# Altium schematic sheet GAP_JTAG_XTALs.SchDoc
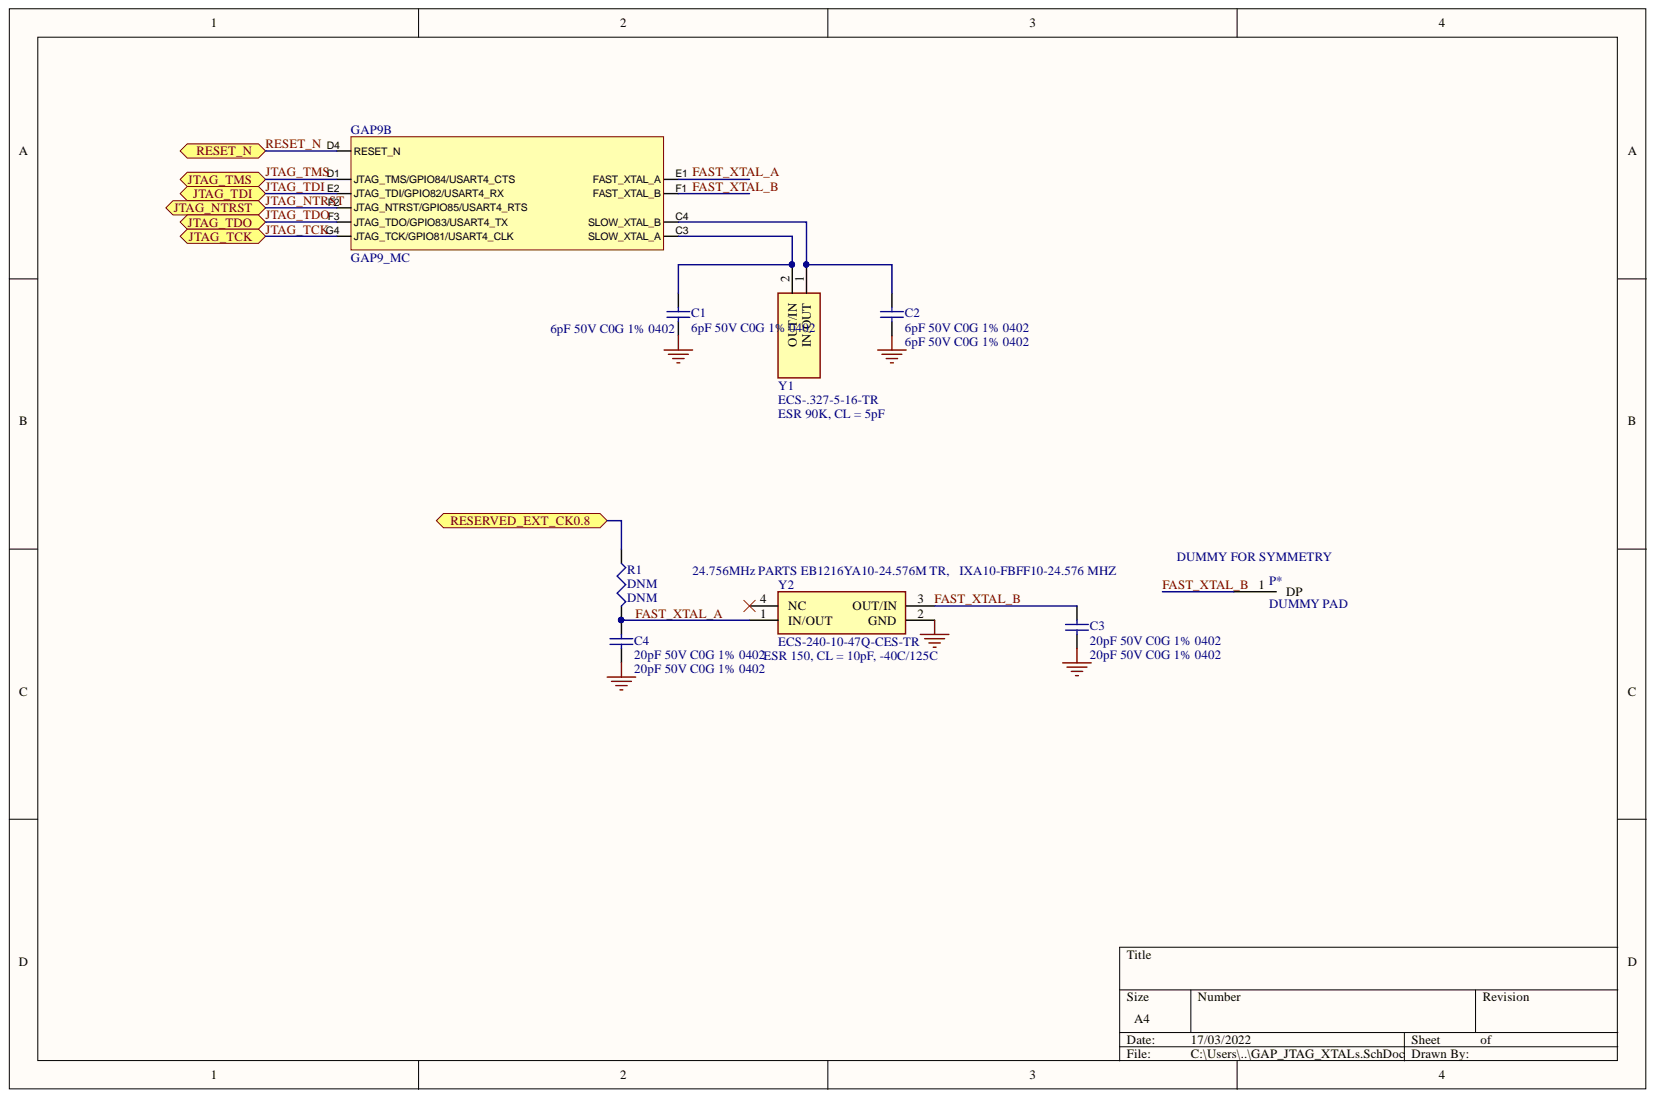
[diagram p0: GAP_JTAG_XTALs.SchDoc]

## Parts
C1  6pF 50V C0G 1% 0402  [0402]
C2  6pF 50V C0G 1% 0402  [0402]
C3  20pF 50V C0G 1% 0402  [0402]
C4  20pF 50V C0G 1% 0402  [0402]
GAP9  GAP9_MC  [GAP9]  (part B)
P*  DUMMY PAD  [0402_PAD]
R1  DNM  [0402]
Y1  ECS-.327-5-16-TR  [ECS327516TR]
Y2  ECS-240-10-47Q-CES-TR  [ECS260847QCESTR]

## Ports
JTAG_NTRST, JTAG_TCK, JTAG_TDI, JTAG_TDO, JTAG_TMS, RESERVED_EXT_CK0.8, RESET_N

## Nets
GND: C1.1 C2.1 C3.1 C4.1 Y2.2(GND)
FAST_XTAL_A: C4.2 GAP9.E1(FAST_XTAL_A) R1.2 Y2.1(IN/OUT)
FAST_XTAL_B: C3.2 GAP9.F1(FAST_XTAL_B) P*.1 Y2.3(OUT/IN)
JTAG_NTRST: GAP9.F2(JTAG_NTRST/GPIO85/USART4_RTS) ; port JTAG_NTRST
JTAG_TCK: GAP9.G4(JTAG_TCK/GPIO81/USART4_CLK) ; port JTAG_TCK
JTAG_TDI: GAP9.E2(JTAG_TDI/GPIO82/USART4_RX) ; port JTAG_TDI
JTAG_TDO: GAP9.F3(JTAG_TDO/GPIO83/USART4_TX) ; port JTAG_TDO
JTAG_TMS: GAP9.D1(JTAG_TMS/GPIO84/USART4_CTS) ; port JTAG_TMS
RESERVED_EXT_CK0.8: R1.1 ; port RESERVED_EXT_CK0.8
RESET_N: GAP9.D4(RESET_N) ; port RESET_N
NetC1_2: C1.2 GAP9.C3(SLOW_XTAL_A) Y1.2
NetC2_2: C2.2 GAP9.C4(SLOW_XTAL_B) Y1.1

Not connected: Y2 4(NC)

## Library data of the parts
C1-C4 = Cap Semi: Capacitor (Semiconductor SIM Model); Component Kind: Standard; LatestRevisionDate: 29-May-2009; LatestRevisionNote: IPC-7351 Footprint Added.; Library Name: Miscellaneous Devices.IntLib; PackageDescription: Chip Capacitor; PackageReference: C1206; Published: 8-Jun-2000; Signal Integrity: Cap Semi; Simulation: CAP; Publisher: Altium Limited
  C1 C2: Value: 6pF 50V C0G 1% 0402
  C3 C4: Value: 20pF 50V C0G 1% 0402
P* = 0402_PAD: Component Kind: Standard; Library Name: Schlib1.SchLib
R1 = Res3: Resistor; Component Kind: Standard; LatestRevisionDate: 29-May-2009; LatestRevisionNote: IPC-7351 Footprint Added.; Library Name: Miscellaneous Devices.IntLib; PackageDescription: Chip Resistor; PackageReference: J1-0603; Published: 8-Jun-2000; Signal Integrity: Res; Simulation: RESISTOR; Value: DNM; Publisher: Altium Limited
Y1 = ECS-.327-5-16-TR: Crystal or Oscillator; Manufacturer_Name: ECS; Arrow Part Number: ECS-.327-5-16-TR; Arrow Price/Stock: https://www.arrow.com/en/products/ecs-.327-5-16-tr/ecs-international; Component Kind: Standard; Datasheet Link: http://www.ecsxtal.com/store/pdf/ECX-16.pdf; Height: 0.5mm; Manufacturer_Part_Number: ECS-.327-5-16-TR; Mouser Part Number: 520-.327-5-16T; Mouser Price/Stock: https://www.mouser.co.uk/ProductDetail/ECS/ECS-327-5-16-TR?qs=w%2Fv1CP2dgqomTHGhUWSiFw%3D%3D
Y2 = ECS-250-10-47Q-CES-TR: Crystal or Oscillator; Manufacturer_Name: ECS; Component Kind: Standard; Height: 0.4mm; Manufacturer_Part_Number: ECS-240-10-47Q-CES-TR; Mouser Part Number: 520-240-10-47Q-CEST; Mouser Price/Stock: https://www.mouser.it/ProductDetail/ECS/ECS-240-10-47Q-CES-TR/?qs=qSfuJ%252Bfl%2Fd5GXZHvPDhb8g%3D%3D
GAP9 = GAP9_MC: Component Kind: Standard; Library Name: GAP9.SchLib
